# Altium schematic sheet USB_JTAG.SchDoc
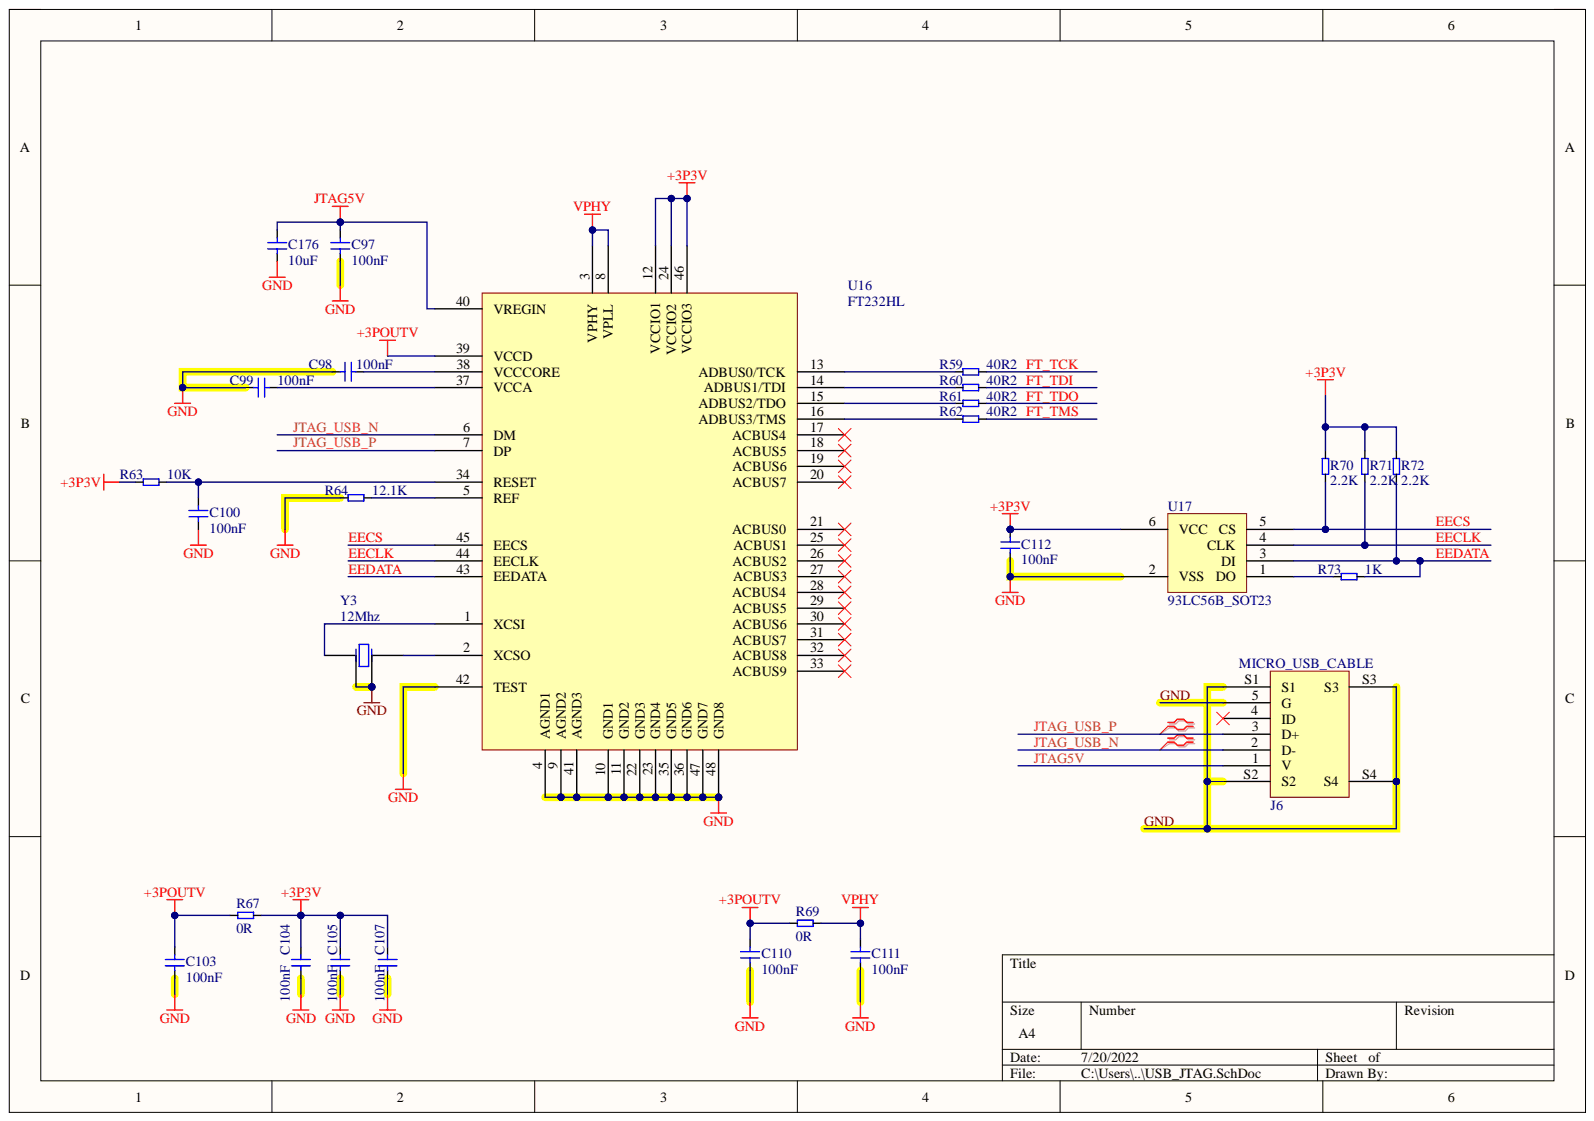
[diagram p0: USB_JTAG.SchDoc]

## Parts
C97  100nF  [C0201]
C98  100nF  [C0201]
C99  100nF  [C0201]
C100  100nF  [C0201]
C103  100nF  [C0201]
C104  100nF  [C0201]
C105  100nF  [C0201]
C107  100nF  [C0201]
C110  100nF  [C0201]
C111  100nF  [C0201]
C112  100nF  [C0201]
C176  10uF  [C0603]
J6  MICRO_USB_CABLE  [USB_5P_F_B_RA_HOLE]
R59  40R2  [R0201]
R60  40R2  [R0201]
R61  40R2  [R0201]
R62  40R2  [R0201]
R63  10K  [R0201]
R64  12.1K  [R0201]
R67  0R  [R0201]
R69  0R  [R0201]
R70  2.2K  [R0201]
R71  2.2K  [R0201]
R72  2.2K  [R0201]
R73  1K  [R0201]
U16  FT232HL  [LC-TQFP-48_7x7x05P]
U17  93LC56B_SOT23  [SOT23-6]
Y3  12Mhz  [OSC_3225]

## Nets
+3P3V: C104.1 C105.1 C107.1 C112.2 R63.1 R67.2 R70.2 R71.2 R72.2 U16.12(VCCIO1) U16.24(VCCIO2) U16.46(VCCIO3) U17.6(VCC)
+3POUTV: C103.2 C110.2 R67.1 R69.1 U16.39(VCCD)
VPHY: C111.2 R69.2 U16.3(VPHY) U16.8(VPLL)
EECLK: R71.1 U16.44(EECLK) U17.4(CLK)
EECS: R70.1 U16.45(EECS) U17.5(CS)
EEDATA: R72.1 R73.2 U16.43(EEDATA) U17.3(DI)
FT_TCK: R59.2
FT_TDI: R60.2
FT_TDO: R61.2
FT_TMS: R62.2
GND: C97.1 C98.1 C99.1 C100.1 C103.1 C104.2 C105.2 C107.2 C110.1 C111.1 C112.1 C176.1 J6.5(G) J6.S1 J6.S2 J6.S3 J6.S4 R64.1 U16.4(AGND1) U16.9(AGND2) U16.10(GND1) U16.11(GND2) U16.22(GND3) U16.23(GND4) U16.35(GND5) U16.36(GND6) U16.41(AGND3) U16.42(TEST) U16.47(GND7) U16.48(GND8) U17.2(VSS) Y3.2 Y3.4
JTAG5V: C97.2 C176.2 J6.1(V) U16.40(VREGIN)
JTAG_USB_N: J6.2(D-) U16.6(DM)
JTAG_USB_P: J6.3(D+) U16.7(DP)
NetC98_2: C98.2 U16.38(VCCCORE)
NetC99_2: C99.2 U16.37(VCCA)
NetC100_2: C100.2 R63.2 U16.34(RESET)
NetR59_1: R59.1 U16.13(ADBUS0/TCK)
NetR60_1: R60.1 U16.14(ADBUS1/TDI)
NetR61_1: R61.1 U16.15(ADBUS2/TDO)
NetR62_1: R62.1 U16.16(ADBUS3/TMS)
NetR64_2: R64.2 U16.5(REF)
NetR73_1: R73.1 U17.1(DO)
NetU16_1: U16.1(XCSI) Y3.1
NetU16_2: U16.2(XCSO) Y3.3

Not connected: J6 4(ID); U16 17(ACBUS4) 18(ACBUS5) 19(ACBUS6) 20(ACBUS7) 21(ACBUS0) 25(ACBUS1) 26(ACBUS2) 27(ACBUS3) 28(ACBUS4) 29(ACBUS5) 30(ACBUS6) 31(ACBUS7) 32(ACBUS8) 33(ACBUS9)

## Library data of the parts
C97-C100 C103-C105 C107 C110-C112 C176 = C: Capacitor; Component Kind: Standard; Library Name: 电容-SCH.LIB
J6 = 1981584-1: Component Kind: Standard
R59-R64 R67 R69-R73 = RES2: Component Kind: Standard; Library Name: 电阻-SCH.LIB
Y3 = SMD-3225_4P  25MHZ 12PF 10PPM: 无源4P贴片晶体3225; Component Kind: Standard; ComponentLink1Description: 供应商链接; ComponentLink1URL: http://www.szlcsc.com/product/details_9526.html; Package: SMD-3225_4P; Supplier: LC; SuppliersPartNumber: C9006; Library Name: ATE公司集成库.IntLib
U16 = FT232HL: Component Kind: Standard; Library Name: Schlib1.SchLib
U17 = 93LC56B_SOT23: Component Kind: Standard; Library Name: XM-JLINK-V1.0.0.SCHLIB
